# Altium schematic sheet power_delivery.SchDoc
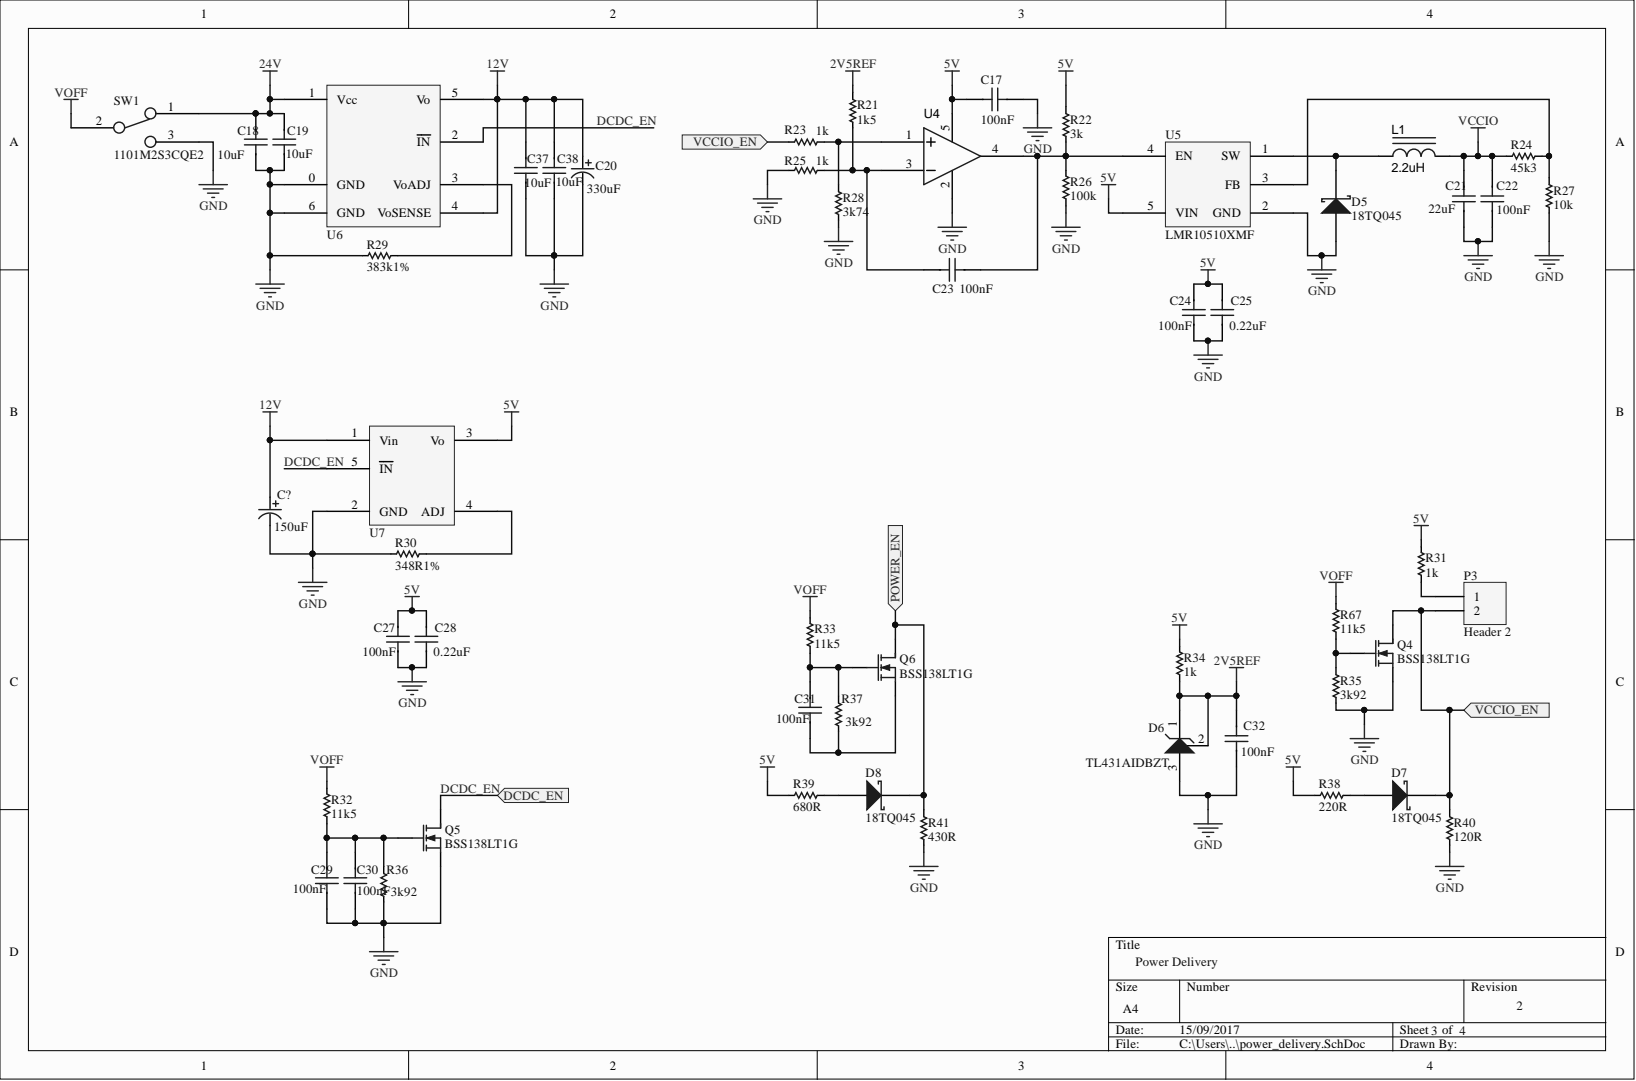
[diagram p0: power_delivery.SchDoc]

## Parts
C17  value 100nF  [0805]  (hidden comment: Capacitor)
C18  value 10uF  [0805]  (hidden comment: Capacitor)
C19  value 10uF  [0805]  (hidden comment: Capacitor)
C20  value 330uF  [CAP - H13]  (hidden comment: Electrolytic Capacitor)
C21  value 22uF  [0805]  (hidden comment: Capacitor)
C22  value 100nF  [0805]  (hidden comment: Capacitor)
C23  value 100nF  [0805]  (hidden comment: Capacitor)
C24  value 100nF  [0805]  (hidden comment: Capacitor)
C25  value 0.22uF  [0805]  (hidden comment: Capacitor)
C27  value 100nF  [0805]  (hidden comment: Capacitor)
C28  value 0.22uF  [0805]  (hidden comment: Capacitor)
C29  value 100nF  [0805]  (hidden comment: Capacitor)
C30  value 100nF  [0805]  (hidden comment: Capacitor)
C31  value 100nF  [0805]  (hidden comment: Capacitor)
C32  value 100nF  [0805]  (hidden comment: Capacitor)
C37  value 10uF  [0805]  (hidden comment: Capacitor)
C38  value 10uF  [0805]  (hidden comment: Capacitor)
C?  value 150uF  [CAP - H13]  (hidden comment: Electrolytic Capacitor)
D5  18TQ045  [POWERDI-123]
D6  TL431AIDBZT  [SOT-23B]
D7  18TQ045  [POWERDI-123]
D8  18TQ045  [POWERDI-123]
L1  2.2uH  [SRN5020-2R2Y_FP]
P3  Header 2  [HDR1X2]
Q4  BSS138LT1G  [SOT-23B]
Q5  BSS138LT1G  [SOT-23B]
Q6  BSS138LT1G  [SOT-23B]
R21  value 1k5  [0805]  (hidden comment: Resistor)
R22  value 3k  [0805]  (hidden comment: Resistor)
R23  value 1k  [0805]  (hidden comment: Resistor)
R24  value 45k3  [0805]  (hidden comment: Resistor)
R25  value 1k  [0805]  (hidden comment: Resistor)
R26  value 100k  [0805]  (hidden comment: Resistor)
R27  value 10k  [0805]  (hidden comment: Resistor)
R28  value 3k74  [0805]  (hidden comment: Resistor)
R29  value 383k1%  [0805]  (hidden comment: Resistor)
R30  value 348R1%  [0805]  (hidden comment: Resistor)
R31  value 1k  [0805]  (hidden comment: Resistor)
R32  value 11k5  [0805]  (hidden comment: Resistor)
R33  value 11k5  [0805]  (hidden comment: Resistor)
R34  value 1k  [0805]  (hidden comment: Resistor)
R35  value 3k92  [0805]  (hidden comment: Resistor)
R36  value 3k92  [0805]  (hidden comment: Resistor)
R37  value 3k92  [0805]  (hidden comment: Resistor)
R38  value 220R  [0805]  (hidden comment: Resistor)
R39  value 680R  [0805]  (hidden comment: Resistor)
R40  value 120R  [0805]  (hidden comment: Resistor)
R41  value 430R  [0805]  (hidden comment: Resistor)
R67  value 11k5  [0805]  (hidden comment: Resistor)
SW1  1101M2S3CQE2  [1101M2S3CQE2_FP]
U4  TLV2702  [SOT23-5]  (value not drawn)
U5  LMR10510XMF  [SOT23-5]
U6  PTN78020HAH  [PTN78020]  (value not drawn)
U7  PTH08080WAH  [PTH08080W_FP]  (value not drawn)

## Ports
DCDC_EN, POWER_EN, VCCIO_EN

## Nets
2V5REF: C32.2 D6.1 D6.2 R21.0 R34.0
5V: C17.1 C24.2 C25.2 C27.2 C28.2 R22.0 R31.1 R34.1 R38.1 R39.1 U4.5 U5.5(VIN) U7.3(Vo)
12V: C20.0 C37.2 C38.2 C?.0 U6.4(VoSENSE) U6.5(Vo) U7.1(Vin)
24V: C18.2 C19.2 SW1.1 U6.1(Vcc)
GND: C17.2 C18.1 C19.1 C20.1 C21.1 C22.1 C24.1 C25.1 C27.1 C28.1 C29.1 C30.1 C32.1 C37.1 C38.1 C?.1 D5.1 D6.3 Q4.2 Q5.2 R25.1 R26.0 R27.1 R28.1 R29.1 R30.1 R35.1 R36.1 R40.1 R41.1 SW1.3 U4.2 U5.2(GND) U6.0(GND) U6.6(GND) U7.2(GND)
VCCIO: C21.2 C22.2 L1.2 R24.1
VOFF: R32.1 R33.1 R67.1 SW1.2
DCDC_EN: Q5.3 U6.2(IN) U7.5(IN) ; port DCDC_EN
POWER_EN: D8.2 Q6.3 R41.0 ; port POWER_EN
VCCIO_EN: D7.2 P3.2 Q4.3 R23.1 R40.0 ; port VCCIO_EN
NetC23_1: C23.1 R21.1 R25.0 U4.3
NetC23_2: C23.2 R22.1 R26.1 U4.4 U5.4(EN)
NetC29_2: C29.2 C30.2 Q5.1 R32.0 R36.0
NetC31_1: C31.1 Q6.2 R37.1
NetC31_2: C31.2 Q6.1 R33.0 R37.0
NetD5_2: D5.2 L1.1 U5.1(SW)
NetD7_1: D7.1 R38.0
NetD8_1: D8.1 R39.0
NetP3_1: P3.1 R31.0
NetQ4_1: Q4.1 R35.0 R67.0
NetR23_0: R23.0 R28.0 U4.1
NetR24_0: R24.0 R27.0 U5.3(FB)
NetR29_0: R29.0 U6.3(VoADJ)
NetR30_0: R30.0 U7.4(ADJ)

## Library data of the parts
D5 D7 D8 = 18TQ045: Schottky Rectifier; Note: Terminal 2 is the TAB and is electrically connected to the cathode; Component Kind: Standard; ComponentLink1Description: Manufacturer Link; ComponentLink1URL: http://www.irf.com/; ComponentLink2Description: Datasheet; ComponentLink2URL: http://www.irf.com/product-info/datasheets/data/18tq.pdf; DatasheetVersion: Dec-1997; LatestRevisionDate: 17-Jul-2002; LatestRevisionNote: Re-released for DXP Platform.; PackageDescription: TO, Thru-Hole, Vertical, Heatsink Mounted; 3 In-Line Leads (1 Absent); Pitch 2.54 mm; PackageReference: TO-220AC; PackageVersion: Feb-1998; Published: 8-Jun-2000; Library Name: SDUEmbedded.SchLib; Publisher: Altium Limited
D6 = TL431AIDBZT: Variable voltage  reference; Note: Pin 2 is not connected and not drawn.; Component Kind: Standard; ComponentLink1Description: Manufacturer Link; ComponentLink1URL: http://www.infineon.com/; ComponentLink2Description: Datasheet; ComponentLink2URL: http://www.infineon.com/upload/Document/cmc_upload/documents/012/5331/bas16series.pdf; DatasheetVersion: Oct-1999; LatestRevisionDate: 29-May-2009; LatestRevisionNote: IPC-7351 Footprint Added.; PackageDescription: 3-Pin SOT-23 Package 0.95 mm Pitch, 2.5 mm Lead Span; PackageReference: SOT-23; PackageVersion: Sep-2001; Published: 21-Mar-2003; Library Name: SDUEmbedded.SchLib; Publisher: Altium Limited
L1 = SRN5020-2R2Y: Manufacturer 1: Cooper Bussmann; Manufacturer Part Number 1: DR73-100-R; Material: ferrite shielded; Ratings: 2.1 A; SCDType: spec; Tolerance: ±20%; Component Kind: Standard; ComponentLink1Description: http://www.cooperindustries.com/content/dam/public/bussmann/Electronics/Resources/Data%20Sheets/Bus_Elx_DS_4315_DR_Series.pdf; ComponentLink1URL: http://www.cooperindustries.com/content/dam/public/bussmann/Electronics/Resources/Data%20Sheets/Bus_Elx_DS_4315_DR_Series.pdf; Description: SRN5020-2R2Y; Package / Case: 5.0x5.0x4.5mm; Supplier 1: Digi-Key; Supplier Part Number 1: 513-1129-1-ND; Value: 2.2uH; Library Name: swarmbot_schematic_library.SchLib
P3 = Header 2: Header, 2-Pin; Component Kind: Standard; LatestRevisionDate: 17-Jul-2002; LatestRevisionNote: Re-released for DXP Platform.; Library Name: Miscellaneous Connectors.IntLib; Signal Integrity: Connector; Publisher: Altium Limited
Q4-Q6 = BSS138LT1G: N-Channel MOSFET; Component Kind: Standard; LatestRevisionDate: 17-Jul-2002; LatestRevisionNote: Re-released for DXP Platform.; PackageDescription: TO, Flat Index; 3 In-Line, Axial Leads; Body Dia. 4.6mm; Lead Dia. 0.5 mm (max); PackageReference: E3; PackageVersion: 1995/1996; Published: 8-Jun-2000; Library Name: SDUEmbedded.SchLib; Publisher: Altium Limited
U4 = LMV331QDBVRQ1: IC SMD AMP+COMPAR Combo SOIC-8; Manufacturer 1: Texas Instruments; Manufacturer Part Number 1: TLV2702ID; SCDType: undef; Voltage - Supply: 2.7 V ~ 16 V, ±1.35 V ~ 8 V; Component Kind: Standard; Package / Case: 8-SOIC (0.154", 3.90mm Width); Supplier 1: Digi-Key; Supplier Part Number 1: 296-12503-5-ND; Library Name: SDUEmbedded.SchLib
C17-C19 C21-C25 C27-C32 C37 C38 = Capacitor: Component Kind: Standard; Description: Capacitor; Library Name: SDUEmbedded.SchLib
C20 C? = Electrolytic Capacitor: Component Kind: Standard; Library Name: SDUEmbedded.SchLib
R21-R41 R67 = Resistor: Component Kind: Standard; Description: Resistor; Library Name: SDUEmbedded.SchLib
SW1 = 1101M2S3CQE2: Component Kind: Standard; Library Name: SDUEmbedded.SchLib
U5 = LMR10510XMF: Component Kind: Standard; Library Name: SDUEmbedded.SchLib
U6 = PTN78020HAH: Component Kind: Standard; Supplier 1: RSComponents; Supplier Part Number 1: 6618171; Library Name: SDUEmbedded.SchLib
U7 = PTH08080WAH: Component Kind: Standard; Supplier 1: RSComponents; Supplier Part Number 1: 661-7948P; Library Name: SDUEmbedded.SchLib
